# Altium schematic sheet Mag_Sense.SchDoc(Mag_Sense)
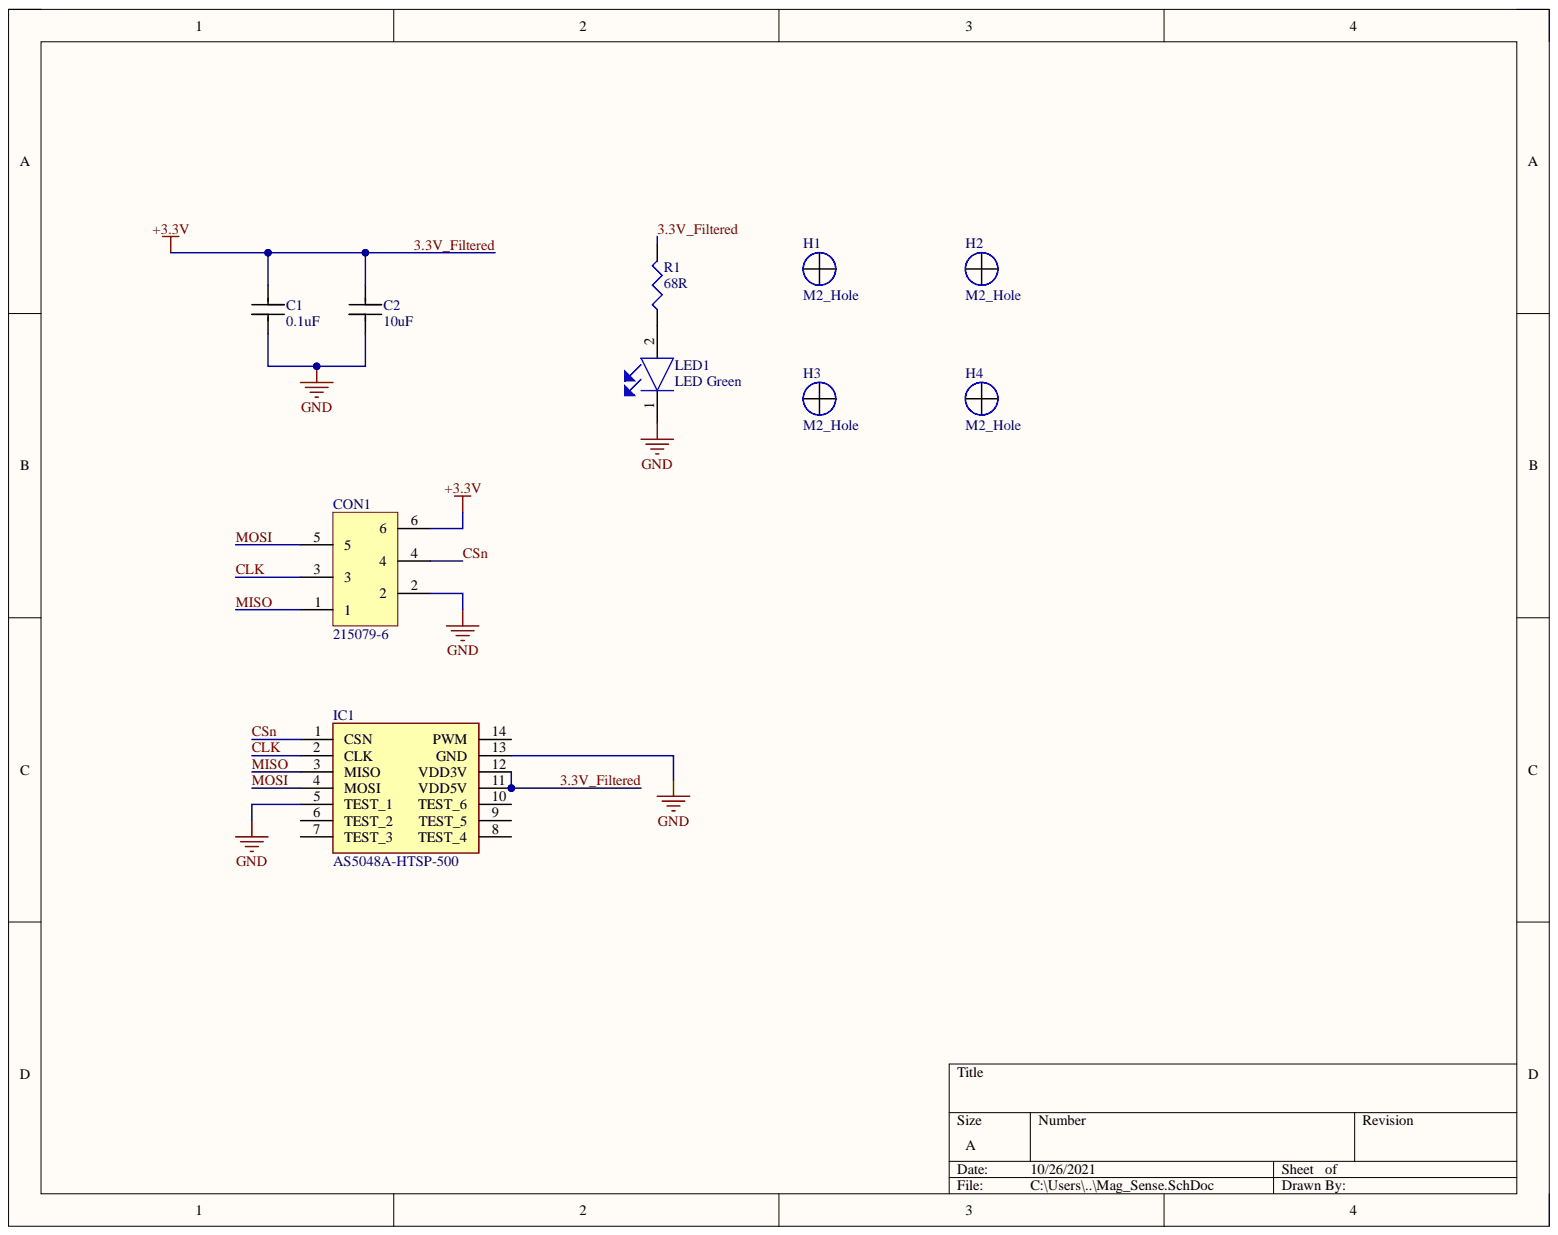
[diagram p0: Mag_Sense.SchDoc]

## Parts
C1  0.1uF  [0402 CAP CAPC1005X55N]
C2  10uF  [Capacitor 0805]
CON1  215079-6  [215079-6]
H1  M2_Hole  [M2_Hole]
H2  M2_Hole  [M2_Hole]
H3  M2_Hole  [M2_Hole]
H4  M2_Hole  [M2_Hole]
IC1  AS5048A-HTSP-500  [SOP65P640X120-14N]
LED1  LED Green  [LEDC1005X35N]
R1  68R  [0402_RES_RESC1005X40N]

## Nets
GND: C1.1 C2.1 CON1.2 IC1.5(TEST_1) IC1.13(GND) LED1.1
3.3V_Filtered: C1.2 C2.2 CON1.6 IC1.11(VDD5V) IC1.12(VDD3V) R1.2
CLK: CON1.3 IC1.2(CLK)
CSn: CON1.4 IC1.1(CSN)
MISO: CON1.1 IC1.3(MISO)
MOSI: CON1.5 IC1.4(MOSI)
NetLED1_2: LED1.2 R1.1

Not connected: H1 1; H2 1; H3 1; H4 1; IC1 6(TEST_2) 7(TEST_3) 8(TEST_4) 9(TEST_5) 10(TEST_6) 14(PWM)

## Library data of the parts
C1 = C1005X7R1H104K050BB: CAP CER 0.1UF 50V X7R 0402; Manufacturer 1: TDK; Capacitance: 100nF; Dielectric Material: Ceramic; Tolerance: 10%; Case Code (Imperial): 0402; Case Code (Metric): 1005; Case/Package: 0402; Component Kind: Standard; ComponentLink1Description: https://datasheet.ciiva.com/16427/c1005x7r1h104k050bb-16427685.pdf?src-supplier=Future+Electronics; ComponentLink1URL: https://datasheet.ciiva.com/16427/c1005x7r1h104k050bb-16427685.pdf?src-supplier=Future+Electronics; ComponentLink2Description: https://datasheet.ciiva.com/26285/2983c1005x7r1h104k050bb-26285417.pdf?src-supplier=Onlinecomponents.com; ComponentLink2URL: https://datasheet.ciiva.com/26285/2983c1005x7r1h104k050bb-26285417.pdf?src-supplier=Onlinecomponents.com; ComponentLink3Description: https://datasheet.ciiva.com/22725/1913358-22725480.pdf?src-supplier=Element14; ComponentLink3URL: https://datasheet.ciiva.com/22725/1913358-22725480.pdf?src-supplier=Element14; ComponentLink4Description: https://datasheet.ciiva.com/6292/tdkmlcccaprange-6292410.pdf?src-supplier=Digi-Key; ComponentLink4URL: https://datasheet.ciiva.com/6292/tdkmlcccaprange-6292410.pdf?src-supplier=Digi-Key; ComponentLink5Description: https://datasheet.ciiva.com/22725/1913358-22725482.pdf?src-supplier=Element14; ComponentLink5URL: https://datasheet.ciiva.com/22725/1913358-22725482.pdf?src-supplier=Element14; ComponentLink6Description: https://datasheet.ciiva.com/48671/e412-c-48671294.pdf?src-supplier=Avnet; ComponentLink6URL: https://datasheet.ciiva.com/48671/e412-c-48671294.pdf?src-supplier=Avnet; ComponentLink7Description: https://datasheet.ciiva.com/17487/e412-c-17487596.pdf?src-supplier=Avnet+Europe; ComponentLink7URL: https://datasheet.ciiva.com/17487/e412-c-17487596.pdf?src-supplier=Avnet+Europe; ComponentLink8Description: https://datasheet.ciiva.com/23802/1796654-23802749.pdf?src-supplier=Newark; ComponentLink8URL: https://datasheet.ciiva.com/23802/1796654-23802749.pdf?src-supplier=Newark; ComponentLink9Description: https://datasheet.ciiva.com/6292/tdkmlcccaprange-6292415.pdf?src-supplier=Digi-Key; ComponentLink9URL: https://datasheet.ciiva.com/6292/tdkmlcccaprange-6292415.pdf?src-supplier=Digi-Key; Composition: Ceramic; Depth: 0.5mm; Dielectric: X7R; Height: 0.5mm; Lead Free: Lead Free; Length: 1mm; Library Name: Schlib1.SchLib; Manufacturer Part Number 1: C1005X7R1H104K050BB; Max Operating Temperature: 125°C; Min Operating Temperature: -55°C; Mount: Surface Mount; Package Quantity: 1; Packaging: Tape and Reel; Pricing 1: 1=0.12000, 10=0.08300, 100=0.03910, 500=0.02742, 1000=0.02269, 2500=0.02112, 5000=0.01932 (USD); Radiation Hardening: No; REACH SVHC: No SVHC; RoHS: Yes; Stock 1: 313215; Supplier 1: Digi-Key; Termination: SMD/SMT; Thickness: 0.022inch; Voltage: 50V; Voltage Rating: 50V; Voltage Rating (DC): 50V; Weight: 2.3E-05oz; Width: 0.5mm
C2 = GMK212BBJ106KG-T: 10uF 35VDC 0805; Manufacturer 1: Taiyo Yuden; Tolerance: 10%; Voltage Rating: 35V; Capacitance: 10uF; Case Code (Imperial): 0805; Case Code (Metric): 2012; Case/Package: 0805; Component Kind: Standard; ComponentLink1Description: http://ds.yuden.co.jp/TYCOMPAS/ut/detail.do?productNo=GMK212BBJ106KG-T&fileName=GMK212BBJ106KG-T_SS&mode=specSheetDownload&src-supplier=Digi-Key; ComponentLink1URL: http://ds.yuden.co.jp/TYCOMPAS/ut/detail.do?productNo=GMK212BBJ106KG-T&fileName=GMK212BBJ106KG-T_SS&mode=specSheetDownload&src-supplier=Digi-Key; ComponentLink2Description: http://www.farnell.com/datasheets/2819844.pdf?src-supplier=Newark; ComponentLink2URL: http://www.farnell.com/datasheets/2819844.pdf?src-supplier=Newark; ComponentLink3Description: http://www.yuden.co.jp/productdata/catalog/mlcc06_e.pdf?src-supplier=Digi-Key; ComponentLink3URL: http://www.yuden.co.jp/productdata/catalog/mlcc06_e.pdf?src-supplier=Digi-Key; Lead Free: Lead Free; Library Name: Schlib1.SchLib; Manufacturer Part Number 1: GMK212BBJ106KG-T; Max Operating Temperature: 85°C; Min Operating Temperature: -55°C; Mount: Surface Mount; Packaging: Cut Tape; Pricing 1: 1=0,78000, 10=0,55200, 100=0,36380, 500=0,26946, 1000=0,22904 (USD); RoHS: No; Stock 1: 92799; Supplier 1: Digi-Key; Supplier Part Number 1: 587-4893-1-ND
CON1 = 215079-6: CONNECTOR, RCPT, 6POS, 2ROW, 1.27MM; Product Range:Micro-MaTch Series; Contact Termination Type:Through Hole; Gender:Receptacle; No. of Contacts:6; No. of Rows:2; Pitch Spacing:1.27mm; Contact Plating:Tin; Contact Material:Phosphor ;RoHS Compliant: Yes; Manufacturer 1: TE Connectivity; Board Standoff: No (Without); Connector System: Board-to-Board; Connector Type: Receptacle,Connector,Pin; Contact Current Rating: 1.5A; Contact Post Length: 0.122inch; Insulation Resistance: 1GR; Max Voltage Rating (DC): 100V; Number of Contacts: 6; PCB Mount Retention: With; PCB Mounting Orientation: Vertical; Pitch: 0.05inch; Plating Thickness: 0.005um; Row Spacing: 0.1inch; Shrouded: Yes (With); Voltage Rating: 230V; Color: Red; Component Kind: Standard; ComponentLink1Description: https://datasheet.ciiva.com/16310/eng-cs-82012-ribbon-cable-interconnect-sol-1109-16310643.pdf?src-supplier=Future+Electronics; ComponentLink1URL: https://datasheet.ciiva.com/16310/eng-cs-82012-ribbon-cable-interconnect-sol-1109-16310643.pdf?src-supplier=Future+Electronics; ComponentLink2Description: https://datasheet.ciiva.com/11745/0900766b80d910b9-11745546.pdf?src-supplier=RSComponents; ComponentLink2URL: https://datasheet.ciiva.com/11745/0900766b80d910b9-11745546.pdf?src-supplier=RSComponents; ComponentLink3Description: https://datasheet.ciiva.com/15176/getdatasheetpartid-309076-15176639.pdf?src-supplier=Verical; ComponentLink3URL: https://datasheet.ciiva.com/15176/getdatasheetpartid-309076-15176639.pdf?src-supplier=Verical; ComponentLink4Description: https://datasheet.ciiva.com/16468/eng-cs-82012-ribbon-cable-interconnect-sol-0412-16468824.pdf?src-supplier=Avnet+Asia; ComponentLink4URL: https://datasheet.ciiva.com/16468/eng-cs-82012-ribbon-cable-interconnect-sol-0412-16468824.pdf?src-supplier=Avnet+Asia; ComponentLink5Description: https://datasheet.ciiva.com/1438/eng-cs-82012-ribbon-cable-interconnect-sol-0412-1438794.pdf?src-supplier=Element14; ComponentLink5URL: https://datasheet.ciiva.com/1438/eng-cs-82012-ribbon-cable-interconnect-sol-0412-1438794.pdf?src-supplier=Element14; ComponentLink6Description: https://datasheet.ciiva.com/16660/eng-cs-82012-ribbon-cable-interconnect-sol-0412-16660347.pdf?src-supplier=Avnet+Europe; ComponentLink6URL: https://datasheet.ciiva.com/16660/eng-cs-82012-ribbon-cable-interconnect-sol-0412-16660347.pdf?src-supplier=Avnet+Europe; ComponentLink7Description: https://datasheet.ciiva.com/30156/eng-cat-889409-0699-96-30156959.pdf?src-supplier=Onlinecomponents.com; ComponentLink7URL: https://datasheet.ciiva.com/30156/eng-cat-889409-0699-96-30156959.pdf?src-supplier=Onlinecomponents.com; Contact Gender: Female; Contact Material: Bronze; Contact Plating: Tin; Current Rating: 1.5A; Depth: 5.1mm; ELV: Compliant; Flammability Rating: UL94 V-0; Gender: Receptacle; Height: 0.16inch; Housing Color: Red; Housing Material: Polyester; Lead Free: Lead Free; Lead Pitch: 1.27mm; Length: 0.382inch; Library Name: Schlib1.SchLib; Manufacturer Part Number 1: 215079-6; Mating Alignment: No (Without); Mating Retention: No (Without); Max Operating Temperature: 105°C; Min Operating Temperature: -40°C; Mount: Through Hole; Number of Positions: 6; Number of Rows: 2; Orientation: Straight; Package Quantity: 2500; Packaging: Tape and Reel; PCB Mount Alignment: No (Without); Pricing 1: 1=0,52000, 10=0,49200, 100=0,37690, 500=0,32774, 1000=0,27039 (USD); Radiation Hardening: No; REACH SVHC: No SVHC; RoHS: Yes; Stock 1: 4419; Supplier 1: Digi-Key; Supplier Part Number 1: A105004CT-ND; Termination: Through Hole
IC1 = AS5048A-HTSP-500: Magnetic Sensor; Manufacturer_Name: ams; Component Kind: Standard; Datasheet Link: http://www.mouser.com/ds/2/588/AS5048_Datasheet-263460.pdf; Height: 1.2mm; Library Name: Schlib1.SchLib; Manufacturer_Part_Number: AS5048A-HTSP-500; Mouser Part Number: 985-AS5048A-HTSP-500; Mouser Price/Stock: https://www.mouser.com/Search/Refine.aspx?Keyword=985-AS5048A-HTSP-500
LED1 = VLMG1500-GS08: VISHAY         VLMG1500-GS08             LED, YELLOW GREEN, 35MCD, 0402  2V 20mA 571nm; Color: Green,Yellow; Forward Current: 30mA; Lens Color: Clear; Lens Style: Rectangular; Number of Elements: 1; Power Dissipation: 75mW; Reverse Voltage: 5V; Viewing Angle: 130°; Case Code (Imperial): 0402; Case Code (Metric): 1005; Case/Package: 0402; Component Kind: Standard; ComponentLink1Description: https://datasheet.ciiva.com/13945/vlmo1500-13945360.pdf?src-supplier=Digi-Key; ComponentLink1URL: https://datasheet.ciiva.com/13945/vlmo1500-13945360.pdf?src-supplier=Digi-Key; ComponentLink2Description: https://datasheet.ciiva.com/12071/vlmo1500-244705-12071911.pdf?src-supplier=Mouser; ComponentLink2URL: https://datasheet.ciiva.com/12071/vlmo1500-244705-12071911.pdf?src-supplier=Mouser; ComponentLink3Description: https://datasheet.ciiva.com/13945/vlmo1500-13945358.pdf?src-supplier=Digi-Key; ComponentLink3URL: https://datasheet.ciiva.com/13945/vlmo1500-13945358.pdf?src-supplier=Digi-Key; ComponentLink4Description: https://datasheet.ciiva.com/30005/vlmo1500-30005134.pdf?src-supplier=Avnet; ComponentLink4URL: https://datasheet.ciiva.com/30005/vlmo1500-30005134.pdf?src-supplier=Avnet; ComponentLink5Description: https://datasheet.ciiva.com/13945/vlmo1500-13945359.pdf?src-supplier=Digi-Key; ComponentLink5URL: https://datasheet.ciiva.com/13945/vlmo1500-13945359.pdf?src-supplier=Digi-Key; Depth: 0.5mm; Dominant Wavelength: 571nm; Forward Voltage: 2V; Height: 0.35mm; Illumination Color: Green; Lead Free: Lead Free; Length: 1mm; Library Name: Schlib1.SchLib; Luminous Intensity: 35mcd; Manufacturer 1: Vishay; Manufacturer Part Number 1: VLMG1500-GS08; Max Operating Temperature: 85°C; Min Operating Temperature: -30°C; Mount: Surface Mount; Number of LEDs: 1; Number of Pins: 2; Package Quantity: 1; Packaging: Tape and Reel; Power Rating: 75mW; Pricing 1: 5=9.08800, 25=8.64300, 100=3.87600, 250=3.77100, 500=2.72400, 1000=1.83600 (EUR); Radiation Hardening: No; REACH SVHC: Unknown; RoHS: Yes; Stock 1: 14416; Supplier 1: Farnell; Test Current: 20mA; Wavelength: 571nm; Width: 0.5mm
R1 = RC0402JR-07100RL: RES SMD 100 OHM 5% 1/16W 0402; Manufacturer 1: Yageo; Max Power Dissipation: 63mW; Resistance: 100R; Temperature Coefficient: 100ppm/°C; Voltage Rating: 50V; Case Code (Imperial): 0402; Case Code (Metric): 1005; Case/Package: 0402; Component Kind: Standard; ComponentLink1Description: https://datasheet.ciiva.com/2452/pyu-r-int-thick-7-2452619.pdf?src-supplier=Digi-Key; ComponentLink1URL: https://datasheet.ciiva.com/2452/pyu-r-int-thick-7-2452619.pdf?src-supplier=Digi-Key; ComponentLink2Description: https://datasheet.ciiva.com/16740/pyu-rc0402-51-rohs-l-6-r-16740924.pdf?src-supplier=Future+Electronics; ComponentLink2URL: https://datasheet.ciiva.com/16740/pyu-rc0402-51-rohs-l-6-r-16740924.pdf?src-supplier=Future+Electronics; ComponentLink3Description: https://datasheet.ciiva.com/12577/pyu-rc0402-51-rohs-l-6-r-12577725.pdf?src-supplier=Mouser; ComponentLink3URL: https://datasheet.ciiva.com/12577/pyu-rc0402-51-rohs-l-6-r-12577725.pdf?src-supplier=Mouser; ComponentLink4Description: https://datasheet.ciiva.com/2452/pyu-r-int-thick-7-2452617.pdf?src-supplier=Digi-Key; ComponentLink4URL: https://datasheet.ciiva.com/2452/pyu-r-int-thick-7-2452617.pdf?src-supplier=Digi-Key; ComponentLink5Description: https://datasheet.ciiva.com/46394/1018594-46394943.pdf?src-supplier=Element14; ComponentLink5URL: https://datasheet.ciiva.com/46394/1018594-46394943.pdf?src-supplier=Element14; ComponentLink6Description: https://datasheet.ciiva.com/7850/1018594-7850134.pdf?src-supplier=Element14; ComponentLink6URL: https://datasheet.ciiva.com/7850/1018594-7850134.pdf?src-supplier=Element14; ComponentLink7Description: https://datasheet.ciiva.com/7850/1018594-7850135.pdf?src-supplier=Element14; ComponentLink7URL: https://datasheet.ciiva.com/7850/1018594-7850135.pdf?src-supplier=Element14; ComponentLink8Description: https://datasheet.ciiva.com/2452/pyu-r-int-thick-7-2452618.pdf?src-supplier=Digi-Key; ComponentLink8URL: https://datasheet.ciiva.com/2452/pyu-r-int-thick-7-2452618.pdf?src-supplier=Digi-Key; Composition: Thick Film; Depth: 0.5mm; Features: Moisture Resistant; Height: 0.016inch; Lead Free: Lead Free; Length: 1mm; Library Name: Schlib1.SchLib; Manufacturer Part Number 1: RC0402JR-07100RL; Max Operating Temperature: 155°C; Military Standard: Not; Min Operating Temperature: -55°C; Number of Terminations: 2; Package Quantity: 1; Packaging: Tape and Reel; Power Rating: 63mW; Pricing 1: 1=0,10000, 10=0,01300, 100=0,00530, 1000=0,00236, 2500=0,00205, 5000=0,00169 (USD); REACH SVHC: No SVHC; RoHS: Yes; Stock 1: 5850295; Supplier 1: Digi-Key; Supplier Part Number 1: 311-100JRCT-ND; Termination: SMD/SMT; Tolerance: 5%; Weight: 2.3E-05oz; Width: 0.02inch
H1-H4 = M2_Hole: Component Kind: Standard; Library Name: Schlib1.SchLib
